# Altium schematic sheet 06-I2C.SchDoc
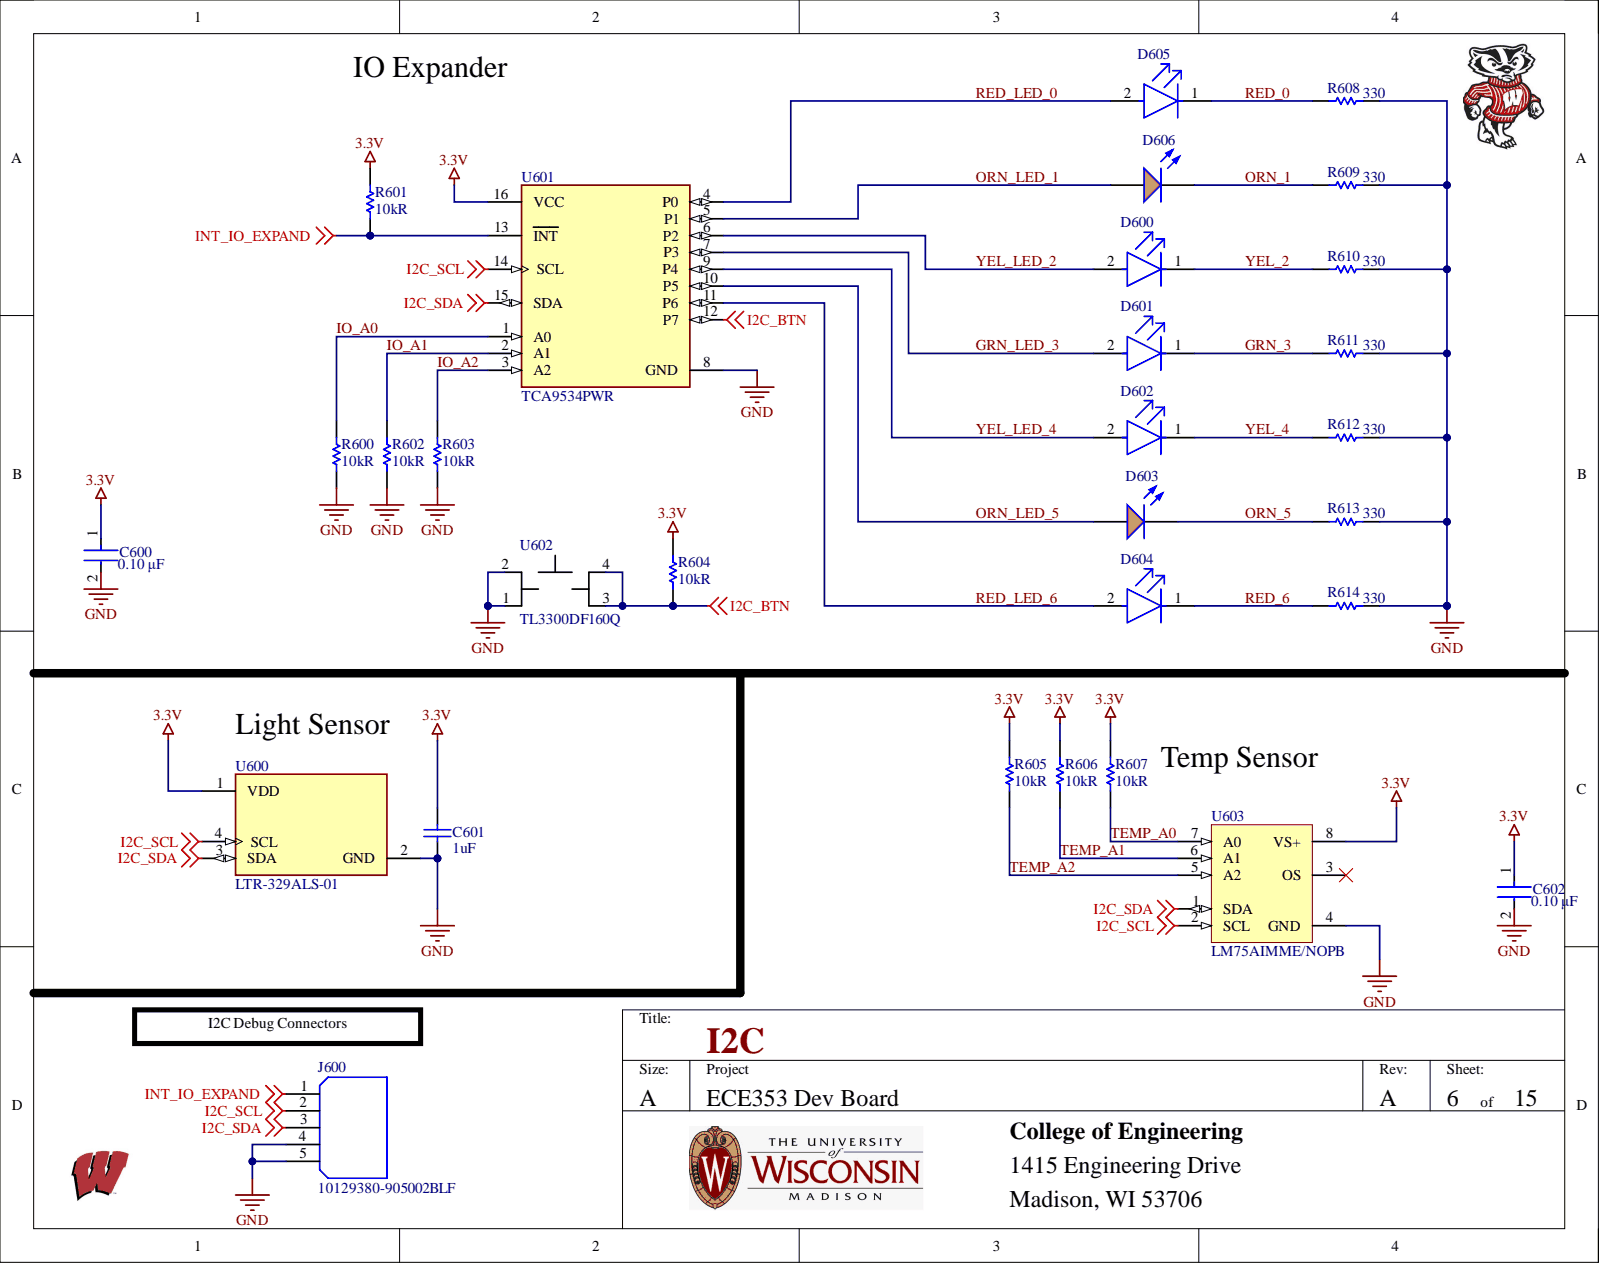
[diagram p0: 06-I2C.SchDoc]

## Parts
C600  value 0.10 μF  [FP-C0805C-DN-MFG]  (hidden comment: C0805C104K5RACTU)
C601  value 1uF  [CAPC2013X145X50LL20T25]  (hidden comment: C0805C105K4PACTU)
C602  value 0.10 μF  [FP-C0805C-DN-MFG]  (hidden comment: C0805C104K5RACTU)
D600  APHCM2012SYCK-F01  [FP-APHCM2012SYCK-F01-MFG]  (value not drawn)
D601  APHCM2012CGCK-F01  [FP-APHCM2012CGCK-F01-MFG]  (value not drawn)
D602  APHCM2012SYCK-F01  [FP-APHCM2012SYCK-F01-MFG]  (value not drawn)
D603  HSMD-C170  [AVAG-HSMX-C170_V]  (value not drawn)
D604  150080SS75000  [FP-150080SS75000-MFG]  (value not drawn)
D605  150080SS75000  [FP-150080SS75000-MFG]  (value not drawn)
D606  HSMD-C170  [AVAG-HSMX-C170_V]  (value not drawn)
J600  10129380-905002BLF  [AMPHENOL_10129380-905002BLF]
R600  Resistance: 10kR  [RESC2013X60X35NL10T20]  (hidden comment: RC0805FR-0710KL)
R601  Resistance: 10kR  [RESC2013X60X35NL10T20]  (hidden comment: RC0805FR-0710KL)
R602  Resistance: 10kR  [RESC2013X60X35NL10T20]  (hidden comment: RC0805FR-0710KL)
R603  Resistance: 10kR  [RESC2013X60X35NL10T20]  (hidden comment: RC0805FR-0710KL)
R604  Resistance: 10kR  [RESC2013X60X35NL10T20]  (hidden comment: RC0805FR-0710KL)
R605  Resistance: 10kR  [RESC2013X60X35NL10T20]  (hidden comment: RC0805FR-0710KL)
R606  Resistance: 10kR  [RESC2013X60X35NL10T20]  (hidden comment: RC0805FR-0710KL)
R607  Resistance: 10kR  [RESC2013X60X35NL10T20]  (hidden comment: RC0805FR-0710KL)
R608  RC0805FR-07330RL  [RESC2013X60X35LL10T20]  (value not drawn)
R609  RC0805FR-07330RL  [RESC2013X60X35LL10T20]  (value not drawn)
R610  RC0805FR-07330RL  [RESC2013X60X35LL10T20]  (value not drawn)
R611  RC0805FR-07330RL  [RESC2013X60X35LL10T20]  (value not drawn)
R612  RC0805FR-07330RL  [RESC2013X60X35LL10T20]  (value not drawn)
R613  RC0805FR-07330RL  [RESC2013X60X35LL10T20]  (value not drawn)
R614  RC0805FR-07330RL  [RESC2013X60X35LL10T20]  (value not drawn)
U600  LTR-329ALS-01  [FP-LTR-329ALS-01-MFG]
U601  TCA9534PWR  [FP-PW0016A-IPC_B]
U602  TL3300DF160Q  [TL3300DF160Q]
U603  LM75AIMME/NOPB  [DGK0008A_L]

## Nets
3.3V: C600.1 C601.2 C602.1 R601.1 R604.1 R605.1 R606.1 R607.1 U600.1(VDD) U601.16(VCC) U603.8(VS+)
GND: C600.2 C601.1 C602.2 J600.4 J600.5 R600.2 R602.2 R603.2 R608.2 R609.2 R610.2 R611.2 R612.2 R613.2 R614.2 U600.2(GND) U601.8(GND) U602.1 U602.2 U603.4(GND)
I2C_BTN: R604.2 U601.12(P7) U602.3 U602.4
I2C_SCL: J600.2 U600.4(SCL) U601.14(SCL) U603.2(SCL)
I2C_SDA: J600.3 U600.3(SDA) U601.15(SDA) U603.1(SDA)
INT_IO_EXPAND: J600.1 R601.2 U601.13(INT)
GRN_3: D601.1 R611.1
GRN_LED_3: D601.2 U601.7(P3)
IO_A0: R600.1 U601.1(A0)
IO_A1: R602.1 U601.2(A1)
IO_A2: R603.1 U601.3(A2)
ORN_1: D606.2 R609.1
ORN_5: D603.2 R613.1
ORN_LED_1: D606.1 U601.5(P1)
ORN_LED_5: D603.1 U601.10(P5)
RED_0: D605.1 R608.1
RED_6: D604.1 R614.1
RED_LED_0: D605.2 U601.4(P0)
RED_LED_6: D604.2 U601.11(P6)
TEMP_A0: R607.2 U603.7(A0)
TEMP_A1: R606.2 U603.6(A1)
TEMP_A2: R605.2 U603.5(A2)
YEL_2: D600.1 R610.1
YEL_4: D602.1 R612.1
YEL_LED_2: D600.2 U601.6(P2)
YEL_LED_4: D602.2 U601.9(P4)

Not connected: U603 3(OS)

## Library data of the parts
C600 C602 = CMP-2007-00279-2: CAP CER 0.1UF 50V X7R 0805; Manufacturer: KEMET; Dielectric Material: Ceramic; Temperature Coefficient: 30ppm/°C; Tolerance: 0.1; Voltage: 500V; Automotive: No; Capacitance: 0.10 μF; Case Code (Imperial): 0805; Case Code (Metric): 2012; Case/Package: 0805; Category: Cap; Component Kind: Standard; ComponentLink2Description: Datasheet; ComponentLink2URL: https://content.kemet.com/datasheets/KEM_C1003_C0G_SMD.pdf; Construction: Flat; Contact Plating: Tin,Matte; Depth: 1.25mm; Device Class L1: Passive Components; Device Class L2: Capacitors; Device Class L3: Ceramic Capacitors; Dielectric: X7R; Digikey Description: CAP CER 0.1UF 50V X7R 0805; Height: 0.88mm; Ipc Land Pattern Name: CAPC200125X78; Lead Free: Yes; Lead Pitch: 0.75mm; Length: 2mm; Library Name: Altium Content Vault; Manufacturer 1: KEMET; Manufacturer Part Number: C0805C104K5RACTU; Manufacturer Part Number 1: C0805C104K5RACTU; Material: Ceramic; Max Operating Temperature: 125°C; Min Operating Temperature: -55°C; Mount: Surface Mount,PCB; Mouser Description: Cap Ceramic 0.1uF 50V X7R 10% SMD 2.0 x 1.2 mm 125 C Paper T/R; Number of Pins: 2; Octopart: https://octopart.com/c0805c104k5ractu-kemet-143926; Package: C0805C-DN; Package Quantity: 4000; Packaging: Tape and Reel; Pricing 1: 1=0.14000, 10=0.09700, 50=0.05480, 100=0.04540, 500=0.03182, 1000=0.02634 (USD); Radiation Hardening: No; Reach SVHC Compliant: Yes; RoHS: TRUE; RoHS Compliant: Yes; Series: SMD Comm X7R; Stock 1: 2163733; Supplier 1: Digi-Key; Supplier Part Number 1: 399-C0805C104K5RAC7800CT-ND; Temperature: -55°C to +125°C; Temperature Characteristic: X7R; Temperature Range High: +125°C; Temperature Range Low: -55°C; Termination: SMD/SMT; Thickness: 0.035inch; Voltage Rating: 50 V; Voltage Rating (DC): 50V; Width: 1.2mm
C601 = CMP-1036-04746-2: Manufacturer: Kemet; Dielectric: X5R; Dielectric Material: Ceramic; Dissipation Factor: 5%; Tolerance: 10%; Voltage: 16V; Case Code (Imperial): 0805; Case Code (Metric): 2012; Case/Package: 0805; Category: Ceramic Capacitors; Component Kind: Standard; ComponentLink1Description: http://www.farnell.com/datasheets/2745930.pdf?src-supplier=Farnell; ComponentLink1URL: http://www.farnell.com/datasheets/2745930.pdf?src-supplier=Farnell; ComponentLink2Description: http://components.arrow.com/part/dataview/43037300S8313636N7429?src-supplier=Arrow+Electronics; ComponentLink2URL: http://components.arrow.com/part/dataview/43037300S8313636N7429?src-supplier=Arrow+Electronics; ComponentLink3Description: https://api.kemet.com/component-edge/download/datasheet/C0805C105K4PAC.pdf?src-supplier=Digi-Key; ComponentLink3URL: https://api.kemet.com/component-edge/download/datasheet/C0805C105K4PAC.pdf?src-supplier=Digi-Key; ComponentLink4Description: http://www.farnell.com/datasheets/2745930.pdf?src-supplier=Element14; ComponentLink4URL: http://www.farnell.com/datasheets/2745930.pdf?src-supplier=Element14; ComponentLink5Description: https://www.verical.com/server-webapp/html/getDatasheet?partID=127986&src-supplier=Verical; ComponentLink5URL: https://www.verical.com/server-webapp/html/getDatasheet?partID=127986&src-supplier=Verical; ComponentLink6Description: https://search.kemet.com/component-edge/download/datasheet/C0805C105K4PAC.pdf?src-supplier=Allied+Electronics; ComponentLink6URL: https://search.kemet.com/component-edge/download/datasheet/C0805C105K4PAC.pdf?src-supplier=Allied+Electronics; ComponentLink7Description: http://www.farnell.com/datasheets/1824493.pdf?src-supplier=Newark; ComponentLink7URL: http://www.farnell.com/datasheets/1824493.pdf?src-supplier=Newark; ComponentLink8Description: http://www.farnell.com/datasheets/2745930.pdf?src-supplier=Newark; ComponentLink8URL: http://www.farnell.com/datasheets/2745930.pdf?src-supplier=Newark; ComponentLink9Description: https://search.kemet.com/component-edge/download/datasheet/C0805C105K4PAC.pdf?src-supplier=Avnet; ComponentLink9URL: https://search.kemet.com/component-edge/download/datasheet/C0805C105K4PAC.pdf?src-supplier=Avnet; ComponentLink10Description: http://www.kemet.com/Lists/ProductCatalog/Attachments/19/KEM_C1006_X5R_SMD.pdf?src-supplier=Avnet; ComponentLink10URL: http://www.kemet.com/Lists/ProductCatalog/Attachments/19/KEM_C1006_X5R_SMD.pdf?src-supplier=Avnet; ComponentLink11Description: https://search.kemet.com/component-edge/download/datasheet/C0805C105K4PAC.pdf?src-supplier=Mouser; ComponentLink11URL: https://search.kemet.com/component-edge/download/datasheet/C0805C105K4PAC.pdf?src-supplier=Mouser; ComponentLink12Description: https://search.kemet.com/component-edge/download/datasheet/C0805C105K4PAC.pdf?src-supplier=TTI; ComponentLink12URL: https://search.kemet.com/component-edge/download/datasheet/C0805C105K4PAC.pdf?src-supplier=TTI; Construction: Flat; Depth: 1.25mm; Height: 1.25mm; Lead Free: Lead Free; Length: 2mm; Library Name: C0805C105K4PACTU.SchLib; Manufacturer Part Number: C0805C105K4PACTU; Max Operating Temperature: 85°C; Min Operating Temperature: -55°C; Mounting Technology: Surface Mount,PCB; Package Quantity: 2500; Packaging: Tape and Reel; Part Number: C0805C105K4PACTU; Pricing: 1=0.2, 10=0.136, 50=0.0814, 100=0.0687, 500=0.047, 1000=0.04158 (USD); Radiation Hardening: No; Reach SVHC Compliant: No; RoHS: Yes; RoHS Compliant: Yes; Series: C0805C; Stock: 65284; Supplier: Digi-Key; Supplier Part Number: 399-C0805C105K4PAC7800CT-ND; Termination: SMD/SMT; Thickness: 0.055inch; Voltage Rating: 16V; Voltage Rating (DC): 16V; Width: 1.2mm
D600 D602 = CMP-44529-000064-1: LED YELLOW CLEAR CHIP SMD; Manufacturer 1: Kingbright; Dominant Wavelength: 590nm; Forward Current: 20mA; Forward Voltage: 2V; Illumination Color: Green; Luminous Intensity: 150mcd; Number of LEDs: 1; Viewing Angle: 110°; Case Code (Imperial): 0805; Case Code (Metric): 2012; Case/Package: 0805; Category: LEDs; Color: Yellow; Component Kind: Standard; ComponentLink1Description: https://datasheet.ciiva.com/2113/aphcm2012syck-f01-2113897.pdf?src-supplier=Digi-Key; ComponentLink1URL: https://datasheet.ciiva.com/2113/aphcm2012syck-f01-2113897.pdf?src-supplier=Digi-Key; ComponentLink2Description: https://datasheet.ciiva.com/12400/aphcm2012syck-f01-29866-12400924.pdf?src-supplier=Mouser; ComponentLink2URL: https://datasheet.ciiva.com/12400/aphcm2012syck-f01-29866-12400924.pdf?src-supplier=Mouser; ComponentLink3Description: https://datasheet.ciiva.com/16494/aphcm2012syck-f01-16494099.pdf?src-supplier=Future+Electronics; ComponentLink3URL: https://datasheet.ciiva.com/16494/aphcm2012syck-f01-16494099.pdf?src-supplier=Future+Electronics; ComponentLink4Description: https://datasheet.ciiva.com/2113/aphcm2012syck-f01-2113899.pdf?src-supplier=Digi-Key; ComponentLink4URL: https://datasheet.ciiva.com/2113/aphcm2012syck-f01-2113899.pdf?src-supplier=Digi-Key; Height: 0.4mm; Lead Free: Lead Free; Length: 2mm; Lens Color: Clear; Lens Style: Clear; Library Name: Altium Content Vault; Manufacturer Part Number 1: APHCM2012SYCK-F01; Max Operating Temperature: 85°C; Min Operating Temperature: -40°C; Mount: Surface Mount; Number of Pins: 2; Package Quantity: 2000; Packaging: Tape and Reel; Pricing 1: 1=0.32000, 10=0.24900, 100=0.11180, 500=0.10094, 1000=0.08292 (USD); RoHS: Yes; RoHS Compliant: Yes; Series: APHCM; Stock 1: 139; Supplier 1: Digi-Key; Supplier Part Number 1: 754-1098-1-ND; Test Current: 20mA; Wavelength: 590nm; Width: 1.25mm
D601 = CMP-44529-000062-1: LED GREEN CLEAR CHIP SMD; Manufacturer 1: Kingbright; Dominant Wavelength: 570nm; Forward Current: 20mA; Forward Voltage: 2.1V; Luminous Intensity: 40mcd; Number of LEDs: 1; Viewing Angle: 110°; Case Code (Imperial): 0805; Case Code (Metric): 2012; Case/Package: 0805; Category: LEDs; Color: Green; Component Kind: Standard; ComponentLink1Description: https://datasheet.ciiva.com/2113/aphcm2012cgck-f01-2113927.pdf?src-supplier=Digi-Key; ComponentLink1URL: https://datasheet.ciiva.com/2113/aphcm2012cgck-f01-2113927.pdf?src-supplier=Digi-Key; ComponentLink2Description: https://datasheet.ciiva.com/12403/aphcm2012cgck-f01-34366-12403909.pdf?src-supplier=Mouser; ComponentLink2URL: https://datasheet.ciiva.com/12403/aphcm2012cgck-f01-34366-12403909.pdf?src-supplier=Mouser; ComponentLink3Description: https://datasheet.ciiva.com/16494/aphcm2012cgck-f01-16494095.pdf?src-supplier=Future+Electronics; ComponentLink3URL: https://datasheet.ciiva.com/16494/aphcm2012cgck-f01-16494095.pdf?src-supplier=Future+Electronics; ComponentLink4Description: https://datasheet.ciiva.com/2113/aphcm2012cgck-f01-2113928.pdf?src-supplier=Digi-Key; ComponentLink4URL: https://datasheet.ciiva.com/2113/aphcm2012cgck-f01-2113928.pdf?src-supplier=Digi-Key; ComponentLink5Description: https://datasheet.ciiva.com/2113/aphcm2012cgck-f01-2113929.pdf?src-supplier=Digi-Key; ComponentLink5URL: https://datasheet.ciiva.com/2113/aphcm2012cgck-f01-2113929.pdf?src-supplier=Digi-Key; Height: 0.4mm; Illumination Color: Green; Lead Free: Lead Free; Length: 2mm; Lens Color: Clear; Lens Style: Clear; Library Name: Altium Content Vault; Manufacturer Part Number 1: APHCM2012CGCK-F01; Max Operating Temperature: 85°C; Min Operating Temperature: -40°C; Mount: Surface Mount; Number of Pins: 2; Package Quantity: 1; Packaging: Tape and Reel; Pricing 1: 1=0.34000, 10=0.26900, 100=0.12090, 500=0.10920, 1000=0.08970 (USD); RoHS: Yes; RoHS Compliant: Yes; Series: APHCM; Stock 1: 63910; Supplier 1: Digi-Key; Supplier Part Number 1: 754-1094-1-ND; Test Current: 20mA; Wavelength: 570nm; Width: 1.25mm
D603 D606 = CMP-2000-06421-1: LED Uni-Color Orange, 52 mW, 20 mA, -40 to 85 degC, 2-Pin SMD, RoHS, Tape and Reel; Manufacturer: Avago; Bulb Size: 1.25mmx1.4mm; Dominant Wavelength: 604nm; External Depth: 0.8mm; External Length / Height: 2mm; External Width: 1.25mm; Forward Current If: 20mA; Forward Voltage: 2.2V; LED Type: Standard; Lens Shape: Rectangular; Luminous Intensity: 8mcd; No. of Pins: 2; Opto Mounting Orientation: Top; Peak Wavelength: 605nm; PIV Max: 5V; Power Dissipation Pd: 52mW; SVHC: NoSVHC(19-Dec-2012); Viewing Angle: 170°; Component Kind: Standard; Datasheet URL: http://docs.avagotech.com/docs/AV02-0551EN; Datasheet Version: 03/2012; Forward Current If Max: 20mA; Forward Voltage VF Max: 2.2V; LED Colour: Orange; LED Mounting: SMD; Library Name: Altium Content Vault; Light Area Height: 1.25mm; Luminous Intensity Typ: 8mcd; Manufacturer URL: http://www.avagotech.com/; Mounting Technology: SurfaceMount; Mounting Type: SMD; MSL: MSL2A-4weeks; Operating Temperature Max: 85°C; Operating Temperature Min: -30°C; Operating Temperature Range: -30°Cto+85°C; Opto Case Style: SMD; Package / Case: SMD; Package Description: 2-Pin Surface Mount Device, Body 2 x 1.25 mm, Height 0.8 mm; Package Reference: HSMX-C170; Peak Forward Current: 20mA; Storage Temperature Max: 85°C; Storage Temperature Min: -40°C; Voltage Vf Typ: 2.2V; Wavelength Typ: 605nm
D604 D605 = CMP-1426-00010-2: LED RED CLEAR 0805 SMD; Manufacturer 1: Wurth Electronics; Dominant Wavelength: 630nm; Forward Current: 30mA; Forward Voltage: 1.9V; Lens Color: Colorless; Lens Size: 1.25mm; Luminous Intensity: 60mcd; Test Current: 20mA; Viewing Angle: 140°; Case Code (Imperial): 0805; Case Code (Metric): 2012; Case/Package: 0805; Category: LEDs; Color: Red; Component Kind: Standard; ComponentLink1Description: https://datasheet.ciiva.com/22656/150080ss75000-22656963.pdf?src-supplier=Element14; ComponentLink1URL: https://datasheet.ciiva.com/22656/150080ss75000-22656963.pdf?src-supplier=Element14; ComponentLink2Description: https://datasheet.ciiva.com/29279/759436-da-01-en-chipled-0805-w-kl-s-rot-150080ss75000-29279211.pdf?src-supplier=Conrad; ComponentLink2URL: https://datasheet.ciiva.com/29279/759436-da-01-en-chipled-0805-w-kl-s-rot-150080ss75000-29279211.pdf?src-supplier=Conrad; ComponentLink3Description: https://datasheet.ciiva.com/29563/150080ss75000-29563014.pdf?src-supplier=Digi-Key; ComponentLink3URL: https://datasheet.ciiva.com/29563/150080ss75000-29563014.pdf?src-supplier=Digi-Key; ComponentLink4Description: https://datasheet.ciiva.com/29563/150080ss75000-29563013.pdf?src-supplier=Digi-Key; ComponentLink4URL: https://datasheet.ciiva.com/29563/150080ss75000-29563013.pdf?src-supplier=Digi-Key; ComponentLink5Description: https://datasheet.ciiva.com/29563/150080ss75000-29563015.pdf?src-supplier=Digi-Key; ComponentLink5URL: https://datasheet.ciiva.com/29563/150080ss75000-29563015.pdf?src-supplier=Digi-Key; Height: 0.8mm; Length: 2mm; Lens Style: Clear; Lens Transparency: Clear; Library Name: Altium Content Vault; Manufacturer Part Number 1: 150080SS75000; Max Operating Temperature: 85°C; Min Operating Temperature: -40°C; Mount: Surface Mount; Package Quantity: 1; Packaging: Cut Tape; Pricing 1: 1=0.19000, 50=0.17600, 100=0.15100, 500=0.13100 (USD); RoHS: Yes; RoHS Compliant: Yes; Series: WL-SMCW; Stock 1: 46386; Supplier 1: Digi-Key; Supplier Part Number 1: 732-4985-1-ND; Wavelength: 630nm; Width: 1.25mm
J600 = 10129380-905002BLF: Conn Unshrouded Header HDR 5 POS 2.54mm Solder ST SMD Poly Bag; Manufacturer 1: ICC; MF: AMPHENOL ICC / FCI; Availability: In Stock; Check_prices: https://www.snapeda.com/parts/10129380-905002BLF/Amphenol/view-part/?ref=eda; Component Kind: Standard; Library Name: ece353-2022.SchLib; Manufacturer Part Number 1: 10129380-905002BLF; MP: 10129380-905002BLF; Package: None; Price: None; Purchase-URL: https://pricing.snapeda.com/search/part/10129380-905002BLF/?ref=eda; RoHS: No; SnapEDA_Link: https://www.snapeda.com/parts/10129380-905002BLF/Amphenol/view-part/?ref=snap; Supplier 1: Digi-Key; Supplier Part Number 1: 10129380-905002BLF-ND
R600-R607 = CMP-1659-00013-4: Chip Resistor, 10 KOhm, +/- 1%, 0.125 W, -55 to 155 degC, 0805 (2012 Metric), RoHS, Tape and Reel; Manufacturer: Yageo; Max Power Dissipation: 125mW; Temperature Coefficient: 100ppm/°C; Voltage Rating: 150 V; Case Code (Imperial): 0805; Case Code (Metric): 2012; Case/Package: 0805; Category: Chip SMD Resistors; Component Kind: Standard; ComponentLink1Description: Manufacturer URL; ComponentLink1URL: http://www.yageo.com/; ComponentLink2Description: Datasheet; ComponentLink2URL: http://www.yageo.com/documents/recent/PYu-RC_Group_51_RoHS_L_04.pdf; Construction: Rectangular; DatasheetVersion: Rev. 4, 02/2016; Depth: 1.25mm; Features: Moisture Resistant; Height: 0.5 mm; Lead Free: Lead Free; Length: 2 mm; Library Name: Altium Content Vault; Manufacturer 1: Yageo; Manufacturer Part Number 1: RC0805FR-0710KL; Max Operating Temperature: 155 degC; Military Standard: Not; Min Operating Temperature: -55 degC; Mount: Surface Mount; Mounting Technology: Surface Mount; Number of Terminations: 2; Package Quantity: 5000; PackageDescription: 2-Pin Surface Mounted Device, Body 2 x 1.25 mm; PackageReference: 0805; Packaging: Tape and Reel; Pins: 2; Power Rating: 125mW; Pricing 1: 1=0.10000, 10=0.03700, 100=0.01520, 1000=0.00682, 2500=0.00592 (USD); REACH SVHC: No SVHC; Resistance Tolerance: 1%; Resistor Type: Thick Film; RoHS: Yes; RoHS Compliant: Yes; RoHSCompliant: true; Series: RC; Size Code: 0805; Stock 1: 12202331; Supplier 1: Digi-Key; Supplier Part Number 1: 311-10.0KCRCT-ND; Technology: THICK FILM; Termination: SMD/SMT; Tolerance: 1%; Width: 0.049inch
R608-R614 = CMP-2000-06960-1: Chip Resistor, 330 Ohm, +/- 1%, 125 mW, -55 to 155 degC, 0805 (2012 Metric), RoHS, Tape and Reel; Manufacturer: Yageo; Max Power Dissipation: 125mW; Power Rating: 125mW(1/8W); Resistance: 330; Temperature Coefficient: 100PPM/C; Voltage Rating: 150V; Case Code (Imperial): 0805; Case Code (Metric): 2012; Case Code - in: 0805; Case Code - mm: 2012; Case/Package: 0805; Category: Chip SMD Resistors; Component Kind: Standard; ComponentLink1Description: https://datasheet.ciiva.com/2457/pyu-r-int-thick-7-2457015.pdf?src-supplier=Digi-Key; ComponentLink1URL: https://datasheet.ciiva.com/2457/pyu-r-int-thick-7-2457015.pdf?src-supplier=Digi-Key; ComponentLink2Description: https://datasheet.ciiva.com/2457/pyu-r-int-thick-7-2457016.pdf?src-supplier=Digi-Key; ComponentLink2URL: https://datasheet.ciiva.com/2457/pyu-r-int-thick-7-2457016.pdf?src-supplier=Digi-Key; ComponentLink3Description: https://datasheet.ciiva.com/2457/pyu-r-int-thick-7-2457014.pdf?src-supplier=Digi-Key; ComponentLink3URL: https://datasheet.ciiva.com/2457/pyu-r-int-thick-7-2457014.pdf?src-supplier=Digi-Key; ComponentLink4Description: https://datasheet.ciiva.com/12667/rc0805-12667925.pdf?src-supplier=Mouser; ComponentLink4URL: https://datasheet.ciiva.com/12667/rc0805-12667925.pdf?src-supplier=Mouser; ComponentLink5Description: https://datasheet.ciiva.com/7941/444164-7941768.pdf?src-supplier=Element14; ComponentLink5URL: https://datasheet.ciiva.com/7941/444164-7941768.pdf?src-supplier=Element14; ComponentLink6Description: https://datasheet.ciiva.com/7941/444164-7941769.pdf?src-supplier=Element14; ComponentLink6URL: https://datasheet.ciiva.com/7941/444164-7941769.pdf?src-supplier=Element14; ComponentLink7Description: https://datasheet.ciiva.com/16740/rc0805-16740975.pdf?src-supplier=Future+Electronics; ComponentLink7URL: https://datasheet.ciiva.com/16740/rc0805-16740975.pdf?src-supplier=Future+Electronics; Construction: Rectangular; Datasheet URL: http://www.yageo.com/documents/recent/PYu-RC_Group_51_RoHS_L_7.pdf; Datasheet Version: Rev. 7, 05/2017; Depth: 1.25mm; Features: Moisture Resistant; Height: 0.024inch; Lead Free: Lead Free; Length: 0.079inch; Library Name: Altium Content Vault; Manufacturer 1: Yageo; Manufacturer Part Number 1: RC0805FR-07330RL; Manufacturer URL: http://www.yageo.com/; Max Operating Temperature: 155°C; Military Standard: Not; Min Operating Temperature: -55°C; Mounting Technology: SurfaceMount; Number of Terminations: 2; Operating Temperature Range: -55Cto+155C; Package Description: 2-Pin Surface Mounted Device, Body 2 x 1.25 mm; Package Quantity: 5000; Package Reference: 0805; Packaging: Reel; Pricing 1: 1=0.10000, 10=0.03700, 100=0.01510, 1000=0.00680, 2500=0.00590 (USD); Product: ThickFilmResistorsGeneralPurpose; Resistance Tolerance: 1%; Resistor Type: Thick Film; RoHS: Yes; RoHS Compliant: Yes; Series: RC; Size Code: 0805; Stock 1: 1297787; Supplier 1: Digi-Key; Supplier Part Number 1: 311-330CRCT-ND; Termination: SMD/SMT; Termination Style: SMD/SMT; Tolerance: 1%; Width: 0.049inch
U600 = CMP-22027-000001-1: SENSOR OPT AMBIENT 4DFN; Manufacturer 1: Vishay Lite-On; Output Type: I2C; Case/Package: SMD/SMT; Category: Photoelectric Sensors; Component Kind: Standard; ComponentLink1Description: http://optoelectronics.liteon.com/upload/download/DS86-2014-0006/LTR-329ALS-01_DS_V1.pdf?src-supplier=Digi-Key; ComponentLink1URL: http://optoelectronics.liteon.com/upload/download/DS86-2014-0006/LTR-329ALS-01_DS_V1.pdf?src-supplier=Digi-Key; Library Name: Altium Content Vault; Manufacturer Part Number 1: LTR-329ALS-01; Max Operating Temperature: 70°C; Min Operating Temperature: -30°C; Mount: Surface Mount; Number of Pins: 4; Packaging: Tape and Reel; Pricing 1: 1=1.06, 5=1.01, 10=0.879, 25=0.772, 50=0.6412, 100=0.5462, 500=0.475, 1000=0.41562 (USD); RoHS: Yes; RoHS Compliant: Yes; Series: -; Stock 1: 25612; Supplier 1: Digi-Key; Supplier Part Number 1: 160-2160-1-ND
U601 = CMP-04886-000003-2: IC I/O EXPANDER I2C 8B 16TSSOP; Manufacturer 1: Texas Instruments; Frequency: 400kHz; Number of I/Os: 8; Case/Package: TSSOP; Category: Interface ICs; Component Kind: Standard; ComponentLink1Description: https://datasheet.ciiva.com/48508/tca9534-48508117.pdf?src-supplier=Avnet; ComponentLink1URL: https://datasheet.ciiva.com/48508/tca9534-48508117.pdf?src-supplier=Avnet; Interface: I2C; Lead Free: Lead Free; Library Name: Altium Content Vault; Manufacturer Part Number 1: TCA9534PWR; Max Operating Temperature: 85°C; Min Operating Temperature: -40°C; Mount: Surface Mount; Number of Outputs: 8; Number of Pins: 16; Packaging: Tape and Reel; Pricing 1: 1=1.45000, 10=1.29500, 25=1.22880, 100=1.00930, 250=0.94352, 500=0.83380, 1000=0.66240 (USD); RoHS: Yes; RoHS Compliant: Yes; Stock 1: 63981; Supplier 1: Digi-Key; Supplier Part Number 1: 296-40574-1-ND
U602 = TL3300DF160Q: Switch Tactile OFF (ON) SPST Round Button Gull Wing 0.05A 12VDC 1.57N SMD; Manufacturer: E-Switch; Actuator Height off PCB, from Pins: 4.30mm; Actuator Orientation: Top Actuated; Actuator Type: Standard; Circuit: SPST-NO; Contact Rating @ Voltage: 0.05A @ 12VDC; Current Rating: 50mA; Illumination: Non-Illuminated; Mechanical Life: 200,000 Cycles; Operating Force: 160gf; Outline: 12.00mm x 12.00mm; Switch Function: Off-Mom; Switch Travel: 0.35mm; Voltage Rating (DC): 12V; Actuator Material: -; Case/Package: SMD/SMT; Category: Switches; Component Kind: Standard; ComponentLink1Description: https://datasheet.ciiva.com/2900/n301101-2900856.pdf?src-supplier=Digi-Key; ComponentLink1URL: https://datasheet.ciiva.com/2900/n301101-2900856.pdf?src-supplier=Digi-Key; ComponentLink2Description: https://datasheet.ciiva.com/2900/n301101-2900858.pdf?src-supplier=Digi-Key; ComponentLink2URL: https://datasheet.ciiva.com/2900/n301101-2900858.pdf?src-supplier=Digi-Key; Contact Plating: Silver; Features: -; Illumination Type: Non-Illuminated; Illumination Type, Color: -; Illumination Voltage (Nominal): -; Ingress Protection: -; Library Name: ece353-2016.SchLib; Manufacturer 1: E-Switch; Manufacturer Part Number: TL3300DF160Q; Manufacturer Part Number 1: TL3300DF160Q; Max Operating Temperature: 70°C; Min Operating Temperature: -25°C; Mount: Surface Mount; Mounting Type: Surface Mount; Operating Temperature: -25°C ~ 70°C; Package Quantity: 1; Packaging: Cut Tape (CT); Pricing 1: 1=0.60000, 10=0.57800, 25=0.55680, 100=0.48180, 250=0.43896 (USD); RoHS: RoHS Compliant; RoHS Compliant: Yes; Series: TL3300; Stock 1: 57403; Supplier: Digi-Key; Supplier 1: Digi-Key; Supplier Part Number: EG4906CT-ND; Supplier Part Number 1: EG4906CT-ND; Termination: Gull Wing; Termination Style: Gull Wing; Throw Configuration: SPST
U603 = CMP-0066-00292-3: Digital Temperature Sensor and Thermal Watchdog with Two-Wire Interface, 8-pin Mini SOIC, Pb-Free; Manufacturer: Texas Instruments; Manufacturer 1: TI National Semiconductor; CompType: Temp Sensor IC; Interface: I2C,SMBus,2-Wire; Max Supply Voltage: 5.5V; Min Supply Voltage: 2.7V; Operating Supply Current: 280uA; Output Current: 1uA; Output Type: Digital,I2C; PackageTopMarking: ZXTT*T00A; PowerWise: N; Resolution: 9b; Case/Package: VSSOP; Code_JEDEC: MO-187-AA; Component Kind: Standard; ComponentLink1Description: Datasheet URL; ComponentLink1URL: http://www.ti.com/general/docs/lit/getliterature.tsp?genericPartNumber=LM75A&fileType=pdf; ComponentLink2Description: https://datasheet.ciiva.com/15098/getdatasheetpartid-629120-15098496.pdf?src-supplier=Verical; ComponentLink2URL: https://datasheet.ciiva.com/15098/getdatasheetpartid-629120-15098496.pdf?src-supplier=Verical; ComponentLink3Description: https://datasheet.ciiva.com/3279/lm75a-3279196.pdf?src-supplier=Avnet; ComponentLink3URL: https://datasheet.ciiva.com/3279/lm75a-3279196.pdf?src-supplier=Avnet; ComponentLink4Description: https://datasheet.ciiva.com/3278/lm75a-3278168.pdf?src-supplier=Avnet; ComponentLink4URL: https://datasheet.ciiva.com/3278/lm75a-3278168.pdf?src-supplier=Avnet; ComponentLink5Description: https://datasheet.ciiva.com/6602/lm75a-6602197.pdf?src-supplier=Digi-Key; ComponentLink5URL: https://datasheet.ciiva.com/6602/lm75a-6602197.pdf?src-supplier=Digi-Key; ComponentLink6Description: https://datasheet.ciiva.com/6602/lm75a-6602198.pdf?src-supplier=Digi-Key; ComponentLink6URL: https://datasheet.ciiva.com/6602/lm75a-6602198.pdf?src-supplier=Digi-Key; Contact Plating: Tin; Height: 0.95mm; Lead Free: Lead Free; Length: 3.1mm; Library Name: Altium Content Vault; Manufacturer Part Number 1: LM75AIMME/NOPB; Max Operating Temperature: 125°C; Max Supply Voltage (DC): 5.5V; Min Operating Temperature: -55°C; Min Supply Voltage (DC): 2.7V; Mount: Surface Mount; Number of Pins: 8; Package Quantity: 250; PackageDescription: MINI SOIC, .118 x .118 x .034in, 8 Lead, .0256in Pitch; PackageReference: DGK0008A; Packaging: Tape and Reel; PinCount: 8; Pricing 1: 1=2.12000, 5=2.00800, 10=1.72200, 25=1.37760, 50=1.26280, 100=1.14800 (USD); Quiescent Current: 280uA; Radiation Hardening: No; Reach SVHC Compliant: Yes; RoHS: Y; RoHS Compliant: Yes; Series: -; Stock 1: 16629; Supplier 1: Digi-Key; Supplier Part Number 1: LM75AIMME/NOPBCT-ND; Width: 3.1mm
